# Altium schematic sheet PT1000_connecteur.SchDoc(PT1000_connecteur)
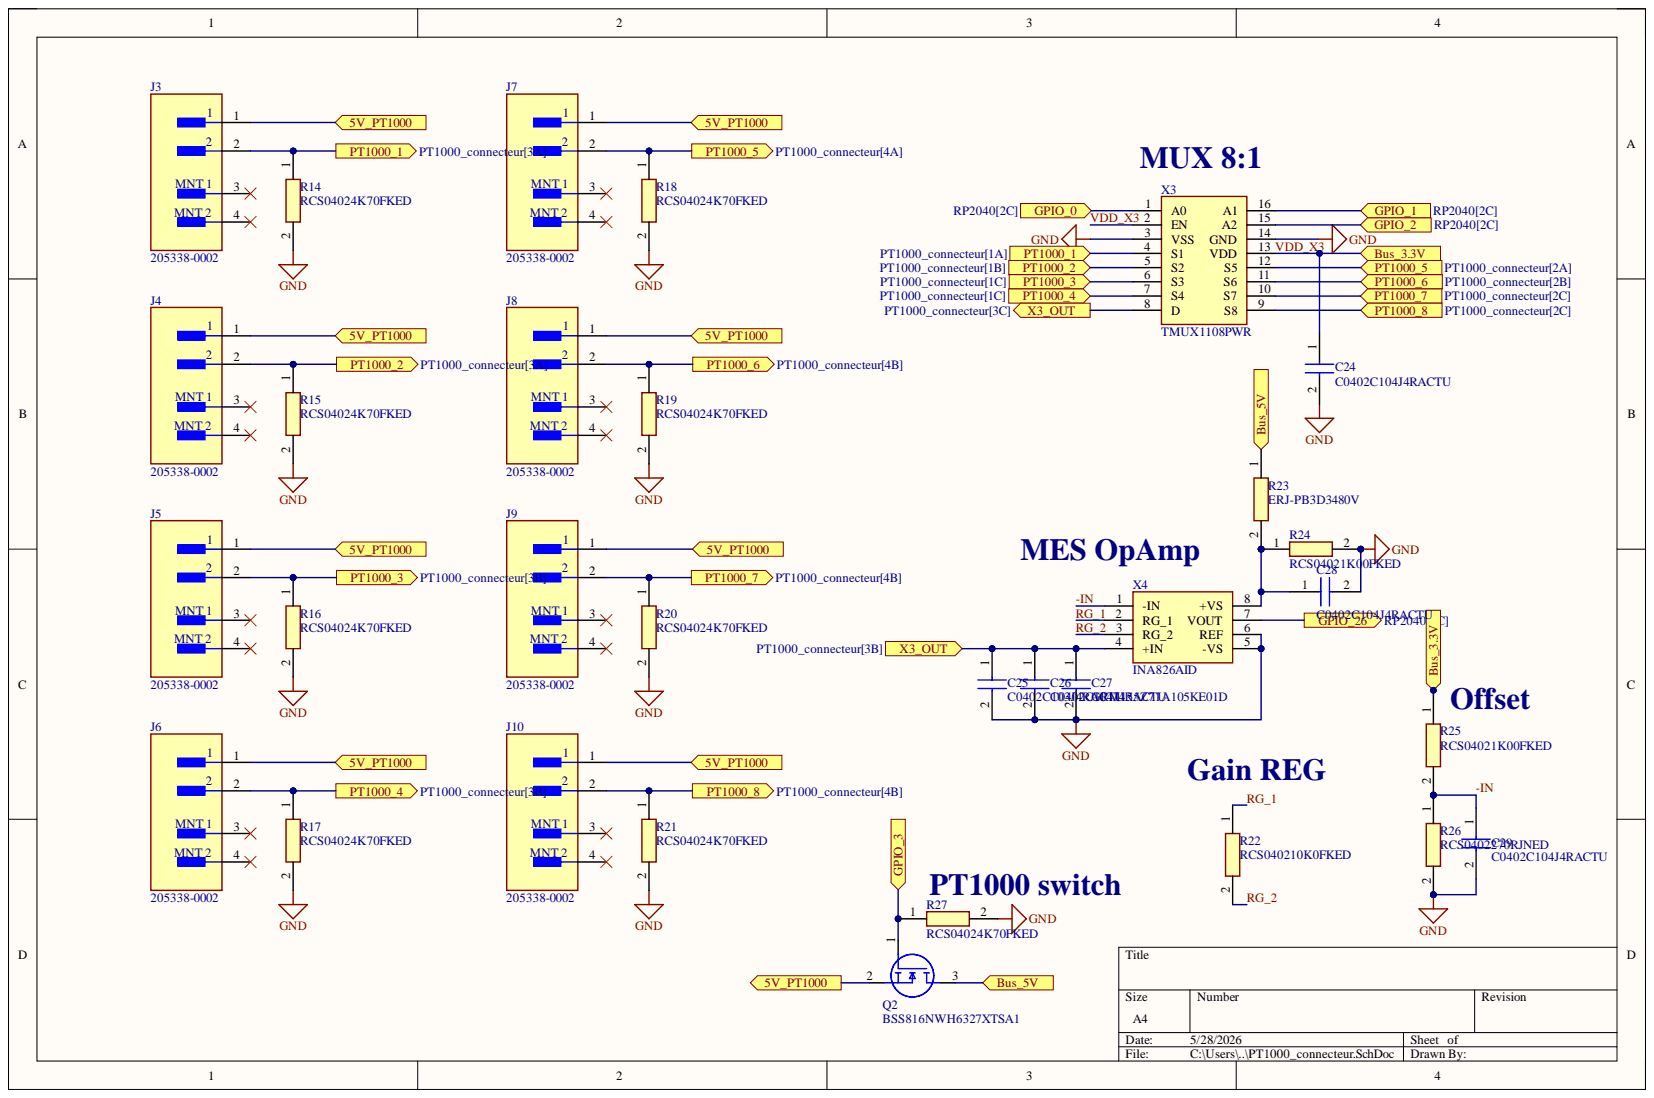
[diagram p0: PT1000_connecteur.SchDoc]

## Parts
C24  C0402C104J4RACTU  [C0402C104J4RACTU]
C25  C0402C103J4RACTU  [CAPC1005X70N]
C26  C0402C104J4RACTU  [C0402C104J4RACTU]
C27  GRM155Z71A105KE01D  [GRM15x]
C28  C0402C104J4RACTU  [C0402C104J4RACTU]
C29  C0402C104J4RACTU  [C0402C104J4RACTU]
J3  205338-0002  [FP-205338-0002-MFG]
J4  205338-0002  [FP-205338-0002-MFG]
J5  205338-0002  [FP-205338-0002-MFG]
J6  205338-0002  [FP-205338-0002-MFG]
J7  205338-0002  [FP-205338-0002-MFG]
J8  205338-0002  [FP-205338-0002-MFG]
J9  205338-0002  [FP-205338-0002-MFG]
J10  205338-0002  [FP-205338-0002-MFG]
Q2  BSS816NWH6327XTSA1  [SOT65P210X100-3N]
R14  RCS04024K70FKED  [RCS0402_E3]
R15  RCS04024K70FKED  [RCS0402_E3]
R16  RCS04024K70FKED  [RCS0402_E3]
R17  RCS04024K70FKED  [RCS0402_E3]
R18  RCS04024K70FKED  [RCS0402_E3]
R19  RCS04024K70FKED  [RCS0402_E3]
R20  RCS04024K70FKED  [RCS0402_E3]
R21  RCS04024K70FKED  [RCS0402_E3]
R22  RCS040210K0FKED  [RCS0402_E3]
R23  ERJ-PB3D3480V  [RCS0402_E3]
R24  RCS04021K00FKED  [RCS0402_E3]
R25  RCS04021K00FKED  [RCS0402_E3]
R26  RCS0402270RJNED  [RCS0402_E3]
R27  RCS04024K70FKED  [RCS0402_E3]
X3  TMUX1108PWR  [SOP65P640X120-16N]
X4  INA826AID  [SOIC127P600X175-8N]

## Ports
5V_PT1000, Bus_3.3V, Bus_5V, GPIO_0, GPIO_1, GPIO_2, GPIO_3, GPIO_26, PT1000_1, PT1000_2, PT1000_3, PT1000_4, PT1000_5, PT1000_6, PT1000_7, PT1000_8, X3_OUT

## Nets
GND: C24.2 C25.2 C26.2 C27.2 C28.2 C29.2 R14.2 R15.2 R16.2 R17.2 R18.2 R19.2 R20.2 R21.2 R24.2 R26.2 R27.2 X3.3(VSS) X3.14(GND) X4.5(-VS) X4.6(REF)
5V_PT1000: J3.1 J4.1 J5.1 J6.1 J7.1 J8.1 J9.1 J10.1 Q2.2 ; port 5V_PT1000
-IN: C29.1 R25.2 R26.1 X4.1(-IN)
Bus_5V: Q2.3 R23.1 ; port Bus_5V
GPIO_0: X3.1(A0) ; port GPIO_0
GPIO_1: X3.16(A1) ; port GPIO_1
GPIO_2: X3.15(A2) ; port GPIO_2
GPIO_3: Q2.1 R27.1 ; port GPIO_3
GPIO_26: X4.7(VOUT) ; port GPIO_26
PT1000_1: J3.2 R14.1 X3.4(S1) ; port PT1000_1
PT1000_2: J4.2 R15.1 X3.5(S2) ; port PT1000_2
PT1000_3: J5.2 R16.1 X3.6(S3) ; port PT1000_3
PT1000_4: J6.2 R17.1 X3.7(S4) ; port PT1000_4
PT1000_5: J7.2 R18.1 X3.12(S5) ; port PT1000_5
PT1000_6: J8.2 R19.1 X3.11(S6) ; port PT1000_6
PT1000_7: J9.2 R20.1 X3.10(S7) ; port PT1000_7
PT1000_8: J10.2 R21.1 X3.9(S8) ; port PT1000_8
RG_1: R22.1 X4.2(RG_1)
RG_2: R22.2 X4.3(RG_2)
VDD_X3: C24.1 R25.1 X3.2(EN) X3.13(VDD) ; port Bus_3.3V
X3_OUT: C25.1 C26.1 C27.1 X3.8(D) X4.4(+IN) ; port X3_OUT
NetC28_1: C28.1 R23.2 R24.1 X4.8(+VS)

Not connected: J3 3 4; J4 3 4; J5 3 4; J6 3 4; J7 3 4; J8 3 4; J9 3 4; J10 3 4

## Library data of the parts
C24 C26 C28 C29 = C0402C104J4RACTU: Condensateur 100nF; Manufacturer_Name: KEMET; Arrow Part Number: C0402C104J4RACTU; Arrow Price/Stock: https://www.arrow.com/en/products/c0402c104j4ractu/kemet-corporation?utm_currency=USD&region=nac; Component Kind: Standard; Datasheet Link: https://content.kemet.com/datasheets/KEM_C1002_X7R_SMD.pdf; Height: 0.55mm; Manufacturer_Part_Number: C0402C104J4RACTU; Mouser Part Number: 80-C0402C104J4R; Mouser Price/Stock: https://www.mouser.co.uk/ProductDetail/KEMET/C0402C104J4RACTU?qs=hOC58ESJ5%2FTi6zdFDTVLPg%3D%3D; Library Name: ADSC_V2.IntLib
C25 = GRM155R61A102KA01D: Capacitor; Manufacturer_Name: Murata Electronics; Arrow Part Number: GRM155R61A102KA01D; Arrow Price/Stock: https://www.arrow.com/en/products/grm155r61a102ka01d/murata-manufacturing?region=nac; Component Kind: Standard; Datasheet Link: https://search.murata.co.jp/Ceramy/image/img/A01X/G101/ENG/GRM155R61A102KA01-01A.pdf; Height: 0.7mm; Manufacturer_Part_Number: GRM155R61A102KA01D; Mouser Part Number: 81-GRM155R61A102KA1D; Mouser Price/Stock: https://www.mouser.co.uk/ProductDetail/Murata-Electronics/GRM155R61A102KA01D?qs=y02iAgv9n635dgph15ru9A%3D%3D; Library Name: ADSC_V2.IntLib
C27 = GRM155Z71A105KE01D: Condensateur 1uF; Manufacturer_Name: Murata Electronics; Component Kind: Standard; Datasheet Link: https://search.murata.co.jp/Ceramy/image/img/A01X/G101/ENG/GRM155Z71A105KE01-01A.pdf; Height: 0.33mm; Manufacturer_Part_Number: GRM155Z71A105KE01D; Mouser Part Number: 81-GRM155Z71A105KE1D; Mouser Price/Stock: https://www.mouser.co.uk/ProductDetail/Murata-Electronics/GRM155Z71A105KE01D?qs=%252B6g0mu59x7LZ5VDL%2FTet%2Fg%3D%3D; Library Name: ADSC_V2.IntLib
J3-J10 = CMP-04776-000388-1: PICO-LOCK 2.0 W/B HEADER ASSY ET; Component Kind: Standard; Library Name: Altium Content Vault
Q2 = BSS816NWH6327XTSA1: MOSFET (N-Channel); Manufacturer_Name: Infineon; Arrow Part Number: BSS816NWH6327XTSA1; Arrow Price/Stock: https://www.arrow.com/en/products/bss816nwh6327xtsa1/infineon-technologies-ag?utm_currency=USD&region=nac; Component Kind: Standard; Datasheet Link: https://www.infineon.com/dgdl/Infineon-BSS816NW-DS-v02_03-en.pdf?fileId=db3a304335113a6301351e704a2e1332; Height: 1mm; Manufacturer_Part_Number: BSS816NWH6327XTSA1; Mouser Part Number: 726-SP000917562; Mouser Price/Stock: https://www.mouser.co.uk/ProductDetail/Infineon-Technologies/BSS816NWH6327XTSA1?qs=2wN1DOYHWdTZaABtZbTahw%3D%3D; Library Name: ADSC_V2.IntLib
R14-R21 R27 = RCS04024K70FKED: Résistance 4.7kohm; Manufacturer_Name: Vishay; Arrow Part Number: RCS04024K70FKED; Arrow Price/Stock: https://www.arrow.com/en/products/rcs04024k70fked/vishay?utm_currency=USD&region=nac; Component Kind: Standard; Datasheet Link: https://www.vishay.com/docs/20065/rcse3.pdf; Manufacturer_Part_Number: RCS04024K70FKED; Mouser Part Number: 71-RCS04024K70FKED; Mouser Price/Stock: https://www.mouser.co.uk/ProductDetail/Vishay-Dale/RCS04024K70FKED?qs=NKmfXavxMaw%252Bu6VUO3JuMQ%3D%3D; Library Name: ADSC_V2.IntLib
R22 = RCS040210K0FKED: Resistor; Manufacturer_Name: Vishay; Arrow Part Number: RCS040210K0FKED; Arrow Price/Stock: https://www.arrow.com/en/products/rcs040210k0fked/vishay?utm_currency=USD&region=nac; Component Kind: Standard; Datasheet Link: https://www.vishay.com/docs/20065/rcse3.pdf; Manufacturer_Part_Number: RCS040210K0FKED; Mouser Part Number: 71-RCS040210K0FKED; Mouser Price/Stock: https://www.mouser.co.uk/ProductDetail/Vishay-Dale/RCS040210K0FKED?qs=NKmfXavxMaxYc15I4rFYIQ%3D%3D; Library Name: ADSC_V2.IntLib
R23 R26 = RCS0402270RJNED: Resistor; Manufacturer_Name: Vishay; Component Kind: Standard; Datasheet Link: https://www.vishay.com/docs/20065/rcse3.pdf; Manufacturer_Part_Number: RCS0402270RJNED; Library Name: ADSC_V2.IntLib
R24 R25 = RCS04021K00FKED: Resistor; Manufacturer_Name: Vishay; Component Kind: Standard; Datasheet Link: https://www.vishay.com/docs/20065/rcse3.pdf; Manufacturer_Part_Number: RCS04021K00FKED; Mouser Part Number: 71-RCS04021K00FKED; Mouser Price/Stock: https://www.mouser.com/Search/Refine.aspx?Keyword=71-RCS04021K00FKED; Library Name: ADSC_V2.IntLib
X3 = TMUX1108PWR: Integrated Circuit; Manufacturer_Name: Texas Instruments; Arrow Part Number: TMUX1108PWR; Arrow Price/Stock: https://www.arrow.com/en/products/tmux1108pwr/texas-instruments?region=nac; Component Kind: Standard; Datasheet Link: http://www.ti.com/lit/ds/symlink/tmux1108.pdf; Height: 1.2mm; Manufacturer_Part_Number: TMUX1108PWR; Mouser Part Number: 595-TMUX1108PWR; Mouser Price/Stock: https://www.mouser.co.uk/ProductDetail/Texas-Instruments/TMUX1108PWR?qs=PqoDHHvF6486MXIgK4oQ5g%3D%3D; Library Name: ADSC_V2.IntLib
X4 = INA826AID: Integrated Circuit; Manufacturer_Name: Texas Instruments; Arrow Part Number: INA826AID; Arrow Price/Stock: https://www.arrow.com/en/products/ina826aid/texas-instruments?utm_currency=USD&region=nac; Component Kind: Standard; Datasheet Link: http://www.ti.com/lit/gpn/ina826; Height: 1.75mm; Manufacturer_Part_Number: INA826AID; Mouser Part Number: 595-INA826AID; Mouser Price/Stock: https://www.mouser.co.uk/ProductDetail/Texas-Instruments/INA826AID?qs=RqytGdBYyUH0CDtkCzl1iw%3D%3D; Library Name: ADSC_V2.IntLib
